# Altium schematic sheet Caudalimetro.SchDoc(Caudalimetro)
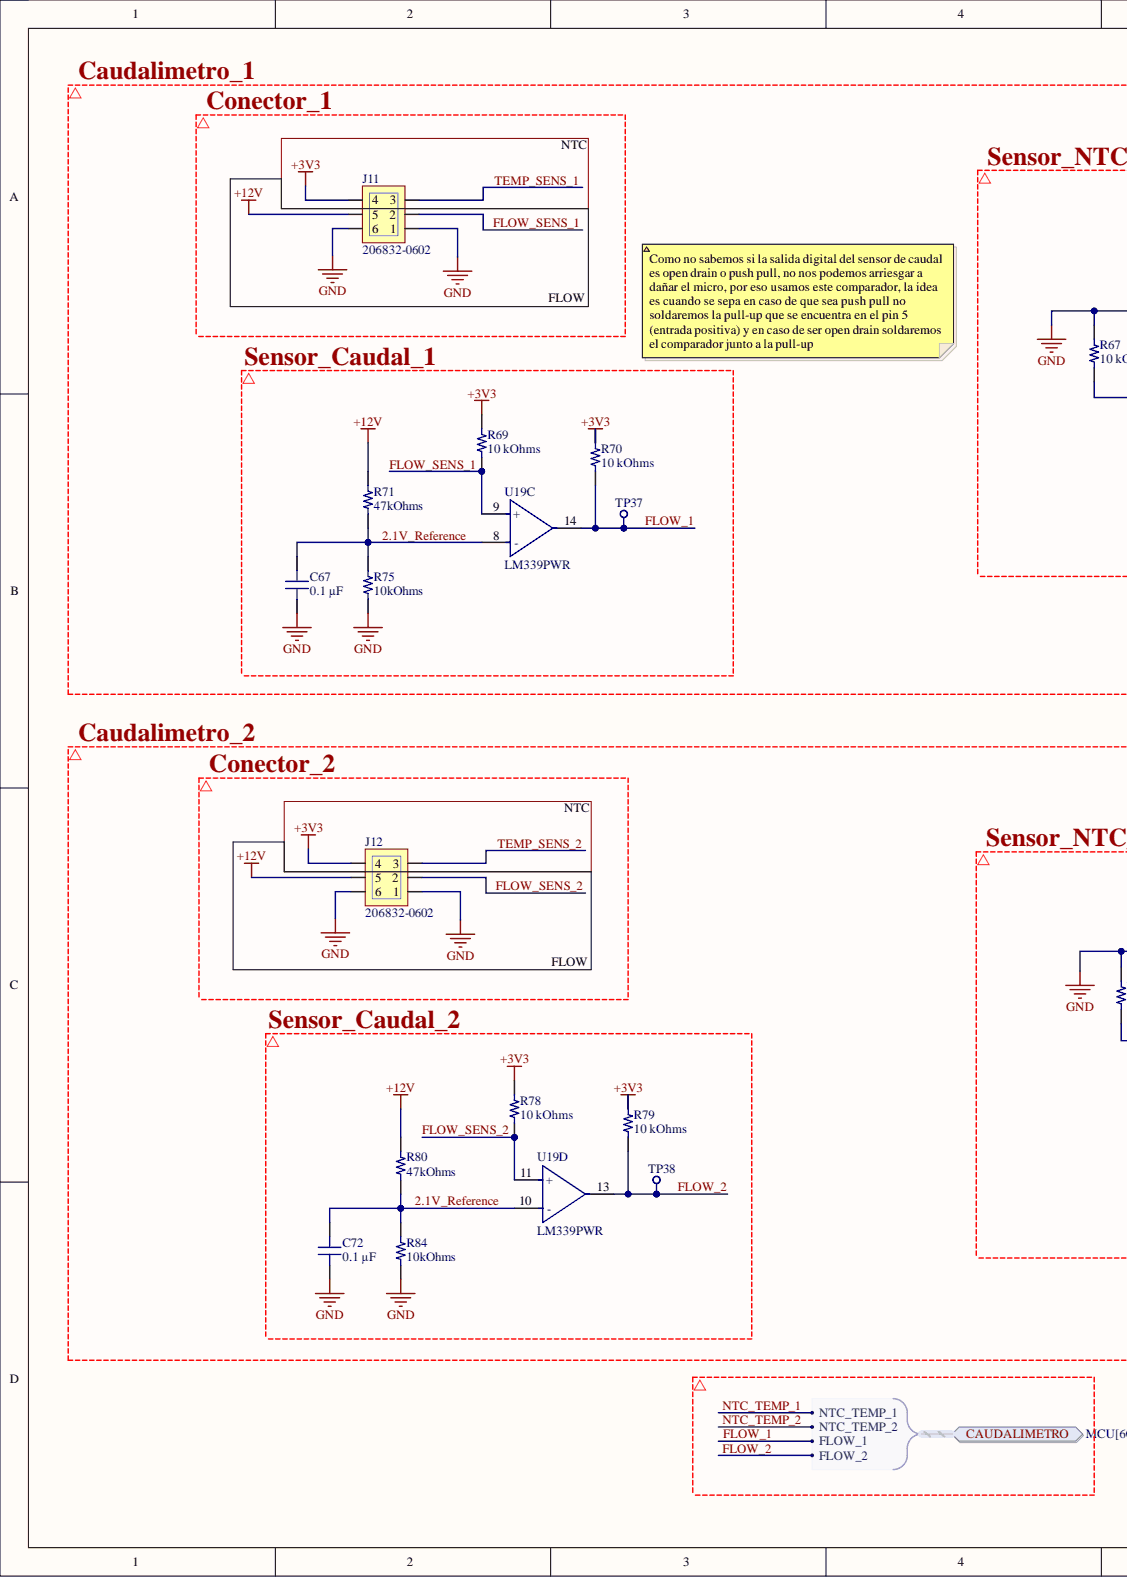
[diagram p0: Caudalimetro.SchDoc, left]
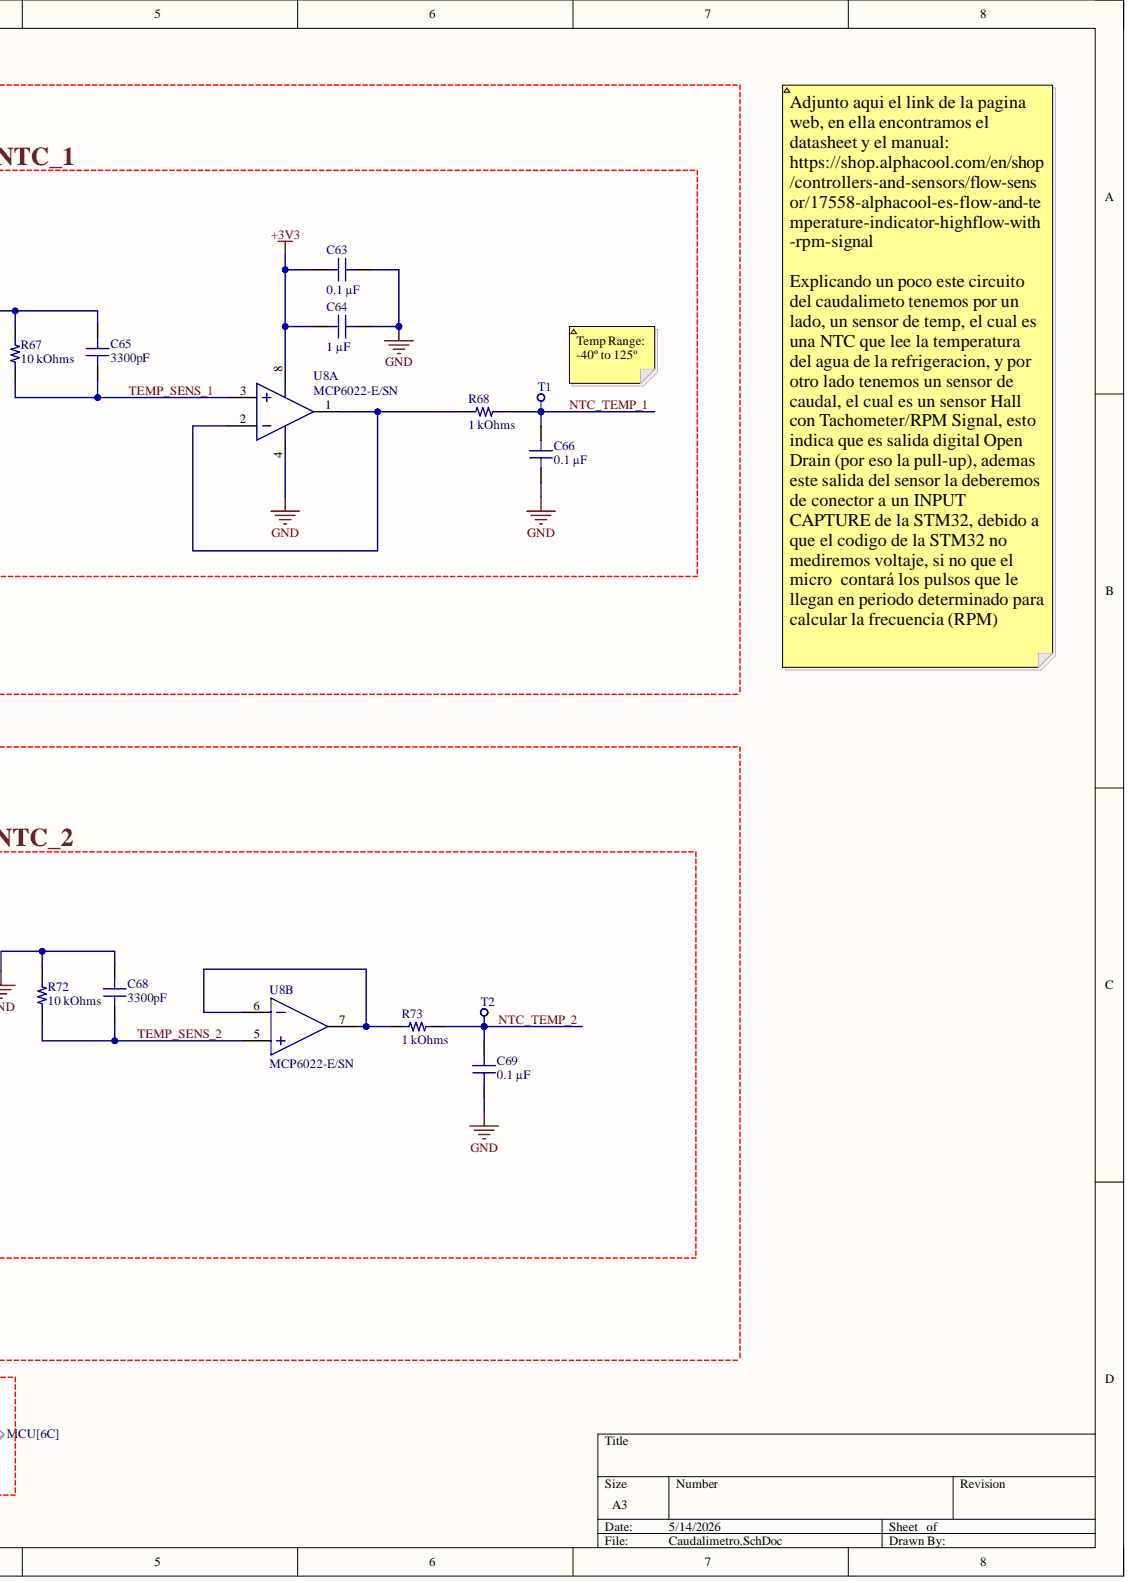
[diagram p1: Caudalimetro.SchDoc, right]

## Parts
C63  0.1 µF
C64  1 µF
C65  3300pF
C66  0.1 µF
C67  0.1 µF
C68  3300pF
C69  0.1 µF
C72  0.1 µF
J11  206832-0602
J12  206832-0602
R67  10 kOhms
R68  1 kOhms
R69  10 kOhms
R70  10 kOhms
R71  47kOhms
R72  10 kOhms
R73  1 kOhms
R75  10kOhms
R78  10 kOhms
R79  10 kOhms
R80  47kOhms
R84  10kOhms
T1  Test point 1.2mm  (value not drawn)
T2  Test point 1.2mm  (value not drawn)
TP37  Test point 1.2mm  (value not drawn)
TP38  Test point 1.2mm  (value not drawn)
U8  MCP6022-E/SN  (parts A,B)
U19  LM339PWR  (parts C,D)

## Ports
CAUDALIMETRO, CAUDALIMETRO.FLOW_1, CAUDALIMETRO.FLOW_2, CAUDALIMETRO.NTC_TEMP_1, CAUDALIMETRO.NTC_TEMP_2

## Nets
+3V3: C63.2 C64.1 J11.4 J12.4 R69.2 R70.2 R78.2 R79.2 U8.8
+12V: J11.5 J12.5 R71.2 R80.2
GND: C63.1 C64.2 C65.2 C66.1 C67.1 C68.2 C69.1 C72.1 J11.1 J11.6 J12.1 J12.6 R67.2 R72.2 R75.1 R84.1 U8.4
2.1V_Reference: C67.2 C72.2 R71.1 R75.2 R80.1 R84.2 U19.8(-) U19.10(-)
FLOW_1: R70.1 TP37.1 U19.14 ; port CAUDALIMETRO
FLOW_2: R79.1 TP38.1 U19.13 ; port CAUDALIMETRO
FLOW_SENS_1: J11.2 R69.1 U19.9(+)
FLOW_SENS_2: J12.2 R78.1 U19.11(+)
NTC_TEMP_1: C66.2 R68.2 T1.1 ; port CAUDALIMETRO
NTC_TEMP_2: C69.2 R73.2 T2.1 ; port CAUDALIMETRO
TEMP_SENS_1: C65.1 J11.3 R67.1 U8.3
TEMP_SENS_2: C68.1 J12.3 R72.1 U8.5
NetR68_1: R68.1 U8.1 U8.2
NetR73_1: R73.1 U8.6 U8.7

## Library data of the parts
C63 = C0603X104K050T: CAP CER 0.1UF 50V X7R 0603; manufacturer: Holy Stone Enterprise Co., Ltd.; Database Table Name: Capacitors - Ceramic - 0603; Tolerance: ±10%; Applications: SMPS Filtering; Capacitance: 0.1 µF; Component Kind: Standard; componentlink1description: Datasheet; componentlink1url: https://www.holystonecaps.com/PDF/201612051329340.2017%20NCC%20Series.pdf; componentlink2description: DigiKey Link; componentlink2url: https://www.digikey.com/en/products/detail/holy-stone-enterprise-co-ltd/C0603X104K050T/16894915; Device Package: 0603 (1608 Metric); lastupdated: 2/9/2024 8:09:56 PM; Library Name: Celestial Altium Library - altium_library.DbLib; Lifecycle Status: Active; Minimum Order: 1; Mounting Type: Surface Mount, MLCC; Operating Temperature: -55°C ~ 125°C; Package / Case: 0603 (1608 Metric); packaging: TapeAndReel; Part Number: C0603X104K050T; Part Status: Active; partid: 35023; price: 0.01; series: MVC; Size / Dimension: 0.063" L x 0.031" W (1.60mm x 0.80mm); Supplier 1: DigiKey; Supplier Part Number 1: 4587-C0603X104K050TCT-ND; Temperature Coefficient: X7R; Thickness (Max): 0.039" (1.00mm); Value: 0.1 µF; Voltage - Rated: 50V
C64 = C1206C105K5RACAUTO: CAP CER 1UF 50V X7R 1206; manufacturer: KEMET; Database Table Name: Capacitors - Ceramic - 1206; Tolerance: ±10%; Applications: Automotive, Bypass, Decoupling; Capacitance: 1 µF; Component Kind: Standard; componentlink1description: Datasheet; componentlink1url: https://api.kemet.com/component-edge/download/datasheet/C1206C105K5RACAUTO.pdf; componentlink2description: DigiKey Link; componentlink2url: https://www.digikey.com/en/products/detail/kemet/C1206C105K5RACAUTO/3315003; Device Package: 1206 (3216 Metric); lastupdated: 6/4/2024 7:10:32 PM; Library Name: Celestial Altium Library - altium_library.DbLib; Lifecycle Status: Active; Minimum Order: 1; Mounting Type: Surface Mount, MLCC; Operating Temperature: -55°C ~ 125°C; Package / Case: 1206 (3216 Metric); packaging: TapeAndReel; Part Number: C1206C105K5RACAUTO; Part Status: Active; partid: 19104; price: 0.05; Ratings: AEC-Q200; series: SMD Auto X7R; Size / Dimension: 0.126" L x 0.063" W (3.20mm x 1.60mm); Supplier 1: DigiKey; Supplier Part Number 1: 399-7011-1-ND; Temperature Coefficient: X7R; Thickness (Max): 0.043" (1.10mm); Value: 1 µF; Voltage - Rated: 50V
C65 C68 = 06035C332JAT2A: CAP CER 3300PF 50V X7R 0603; manufacturer: AVX Corporation; Database Table Name: Capacitors - Ceramic - 0603; Tolerance: ±5%; Applications: General Purpose; Capacitance: 3300pF; Component Kind: Standard; componentlink1description: Datasheet; componentlink1url: http://datasheets.avx.com/X7RDielectric.pdf; componentlink2description: DigiKey Link; componentlink2url: http://digikey.com/product-detail/en/avx-corporation/06035C332JAT2A/478-10808-1-ND/7536175; Device Package: 0603 (1608 Metric); lastupdated: 9/2/2020 1:03:35 AM; Library Name: Celestial Altium Library - altium_library.DbLib; Lifecycle Status: Active; Minimum Order: 1; Mounting Type: Surface Mount, MLCC; Operating Temperature: -55°C ~ 125°C; Package / Case: 0603 (1608 Metric); packaging: TapeAndReel; Part Number: 06035C332JAT2A; Part Status: Active; partid: 2774; price: 0.02; series: -; Size / Dimension: 0.063" L x 0.032" W (1.60mm x 0.81mm); Supplier 1: DigiKey; Supplier Part Number 1: 478-10808-1-ND; Temperature Coefficient: X7R; Thickness (Max): 0.035" (0.90mm); Value: 3300pF; Voltage - Rated: 50V
C66 C67 C69 C72 = CGA3E2X7R1H104K080AE: CAP CER 0.1UF 50V X7R 0603; manufacturer: TDK Corporation; Database Table Name: Capacitors - Ceramic - 0603; Tolerance: ±10%; Applications: Automotive, Boardflex Sensitive; Capacitance: 0.1 µF; Component Kind: Standard; componentlink1description: Datasheet; componentlink1url: https://product.tdk.com/info/en/catalog/datasheets/mlcc_automotive_soft_en.pdf?ref_disty=digikey; componentlink2description: DigiKey Link; componentlink2url: https://www.digikey.com/en/products/detail/tdk-corporation/CGA3E2X7R1H104K080AE/4490594; Device Package: 0603 (1608 Metric); Features: Soft Termination; Library Name: Celestial Altium Library - altium_library.DbLib; Lifecycle Status: Active; Minimum Order: 1; Mounting Type: Surface Mount, MLCC; Operating Temperature: -55°C ~ 125°C; Package / Case: 0603 (1608 Metric); packaging: TapeAndReel; Part Number: CGA3E2X7R1H104K080AE; Part Status: Active; partid: 2715; price: 0.01; Ratings: AEC-Q200; series: CGA; Size / Dimension: 0.063" L x 0.031" W (1.60mm x 0.80mm); Supplier 1: DigiKey; Supplier Part Number 1: 445-15957-1-ND; Temperature Coefficient: X7R; Thickness (Max): 0.037" (0.95mm); Value: 0.1 µF; Voltage - Rated: 50V
  C66 C69: lastupdated: 2/9/2024 8:10:29 PM
  C67 C72: lastupdated: 19/09/2022 13:01:18
J11 J12 = CMP-00106-5: Conector macho para PCB Molex serie Micro-Fit+ de 6 vías, 2 filas, paso 3.0mm, Montaje en orificio pasante; Component Kind: Standard; Library Name: Hyperloop UPV
R67 R69 R72 R78 = MCT06030D1002BP500: RES SMD 10K OHM 0.1% 1/10W 0603; Manufacturer: Vishay Beyschlag; Database Table Name: Resistors - Surface Mount - 0603; Power (Watts): 0.1W, 1/10W; Temperature Coefficient: ±25ppm/°C; Component Kind: Standard; ComponentLink1Description: Datasheet; ComponentLink1URL: http://www.vishay.com/docs/28700/mcx0x0xpre.pdf; ComponentLink2Description: DigiKey Link; ComponentLink2URL: http://digikey.com/product-detail/en/vishay-beyschlag/MCT06030D1002BP500/MCT0603-10K-DBCT-ND/2607937; Composition: Thin Film; Device Package: 0603 (1608 Metric); Features: Anti-Sulfur; Height - Seated (Max): 0.022" (0.55mm); LastUpdated: 2/9/2024 8:43:33 PM; Library Name: Celestial Altium Library - altium_library.DbLib; Lifecycle Status: Active; Minimum Order: 1; Number of Terminations: 2; Operating Temperature: -55°C ~ 125°C; Package / Case: 0603 (1608 Metric); Packaging: TapeAndReel; Part Number: MCT06030D1002BP500; Part Status: Active; PartId: 5804; Price: 0.14; Resistance: 10 kOhms; Series: MCT - Precision; Size / Dimension: 0.061" L x 0.033" W (1.55mm x 0.85mm); Supplier 1: DigiKey; Supplier Device Package: 0603; Supplier Part Number 1: MCT0603-10K-DBCT-ND; Tolerance: ±0.1%; Value: 10 kOhms
R68 R73 = RT0603FRE071KL: RES SMD 1K OHM 1% 1/10W 0603; Manufacturer: Yageo; Database Table Name: Resistors - Surface Mount - 0603; Power (Watts): 0.1W, 1/10W; Temperature Coefficient: ±50ppm/°C; Component Kind: Standard; ComponentLink1Description: Datasheet; ComponentLink1URL: https://www.yageo.com/upload/media/product/productsearch/datasheet/rchip/PYu-RT_1-to-0.01_RoHS_L_11.pdf; ComponentLink2Description: DigiKey Link; ComponentLink2URL: https://www.digikey.com/en/products/detail/yageo/RT0603FRE071KL/1075188; Composition: Thin Film; Device Package: 0603 (1608 Metric); Height - Seated (Max): 0.022" (0.55mm); LastUpdated: 7/25/2024 9:19:32 AM; Library Name: Celestial Altium Library - altium_library.DbLib; Lifecycle Status: Active; Minimum Order: 1; Number of Terminations: 2; Operating Temperature: -55°C ~ 155°C; Package / Case: 0603 (1608 Metric); Packaging: TapeAndReel; Part Number: RT0603FRE071KL; Part Status: Active; PartId: 5672; Price: 0.01; Resistance: 1 kOhms; Series: RT; Size / Dimension: 0.063" L x 0.031" W (1.60mm x 0.80mm); Supplier 1: DigiKey; Supplier Device Package: 0603; Supplier Part Number 1: YAG2323CT-ND; Tolerance: ±1%; Value: 1 kOhms
R70 R79 = RNCS0603BKE10K0: RES 10K OHM 0.1% 1/16W 0603; Manufacturer: Stackpole Electronics Inc; Database Table Name: Resistors - Surface Mount - 0603; Power (Watts): 0.063W, 1/16W; Temperature Coefficient: ±25ppm/°C; Component Kind: Standard; ComponentLink1Description: Datasheet; ComponentLink1URL: https://www.seielect.com/catalog/sei-rncs_rnch.pdf; ComponentLink2Description: DigiKey Link; ComponentLink2URL: https://www.digikey.com/en/products/detail/stackpole-electronics-inc/RNCS0603BKE10K0/1708836; Composition: Thin Film; Device Package: 0603 (1608 Metric); Features: Anti-Corrosive, Automotive AEC-Q200, Moisture Resistant; Height - Seated (Max): 0.022" (0.55mm); LastUpdated: 09-Feb-24 20:43:37; Library Name: Celestial Altium Library - altium_library.DbLib; Lifecycle Status: Active; Minimum Order: 1; Number of Terminations: 2; Operating Temperature: -55°C ~ 155°C; Package / Case: 0603 (1608 Metric); Packaging: TapeAndReel; Part Number: RNCS0603BKE10K0; Part Status: Active; PartId: 4838; Price: 0.06; Ratings: AEC-Q200; Resistance: 10 kOhms; Series: RNCS; Size / Dimension: 0.061" L x 0.031" W (1.55mm x 0.80mm); Supplier 1: DigiKey; Supplier Device Package: 0603; Supplier Part Number 1: RNCS0603BKE10K0CT-ND; Tolerance: ±0.1%; Value: 10 kOhms
R71 R80 = RT0603FRE07100KL: RES SMD 100K OHM 1% 1/10W 0603; Manufacturer: Yageo; Database Table Name: Resistors - Surface Mount - 0603; Power (Watts): 0.1W, 1/10W; Resistance: 100 kOhms; Temperature Coefficient: ±50ppm/°C; Component Kind: Standard; ComponentLink1Description: Datasheet; ComponentLink1URL: https://www.yageo.com/upload/media/product/productsearch/datasheet/rchip/PYu-RT_1-to-0.01_RoHS_L_11.pdf; ComponentLink2Description: DigiKey Link; ComponentLink2URL: https://www.digikey.com/en/products/detail/yageo/RT0603FRE07100KL/1075029; Composition: Thin Film; Device Package: 0603 (1608 Metric); Height - Seated (Max): 0.022" (0.55mm); LastUpdated: 25-Jul-24 09:19:32; Library Name: Celestial Altium Library - altium_library.DbLib; Lifecycle Status: Active; Minimum Order: 1; Number of Terminations: 2; Operating Temperature: -55°C ~ 155°C; Package / Case: 0603 (1608 Metric); Packaging: TapeAndReel; Part Number: RT0603FRE07100KL; Part Status: Active; PartId: 5677; Price: 0.01; Series: RT; Size / Dimension: 0.063" L x 0.031" W (1.60mm x 0.80mm); Supplier 1: DigiKey; Supplier Device Package: 0603; Supplier Part Number 1: YAG2319CT-ND; Tolerance: ±1%; Value: 47kOhms
R75 R84 = AC0603FR-07316KL: RES SMD 316K OHM 1% 1/10W 0603; Manufacturer: Yageo; Database Table Name: Resistors - Surface Mount - 0603; Power (Watts): 0.1W, 1/10W; Resistance: 316 kOhms; Temperature Coefficient: ±100ppm/°C; Component Kind: Standard; ComponentLink1Description: Datasheet; ComponentLink1URL: https://www.yageo.com/upload/media/product/productsearch/datasheet/rchip/PYu-AC_51_RoHS_L_8.pdf; ComponentLink2Description: DigiKey Link; ComponentLink2URL: https://www.digikey.com/en/products/detail/yageo/AC0603FR-07316KL/5896029; Composition: Thick Film; Device Package: 0603 (1608 Metric); Features: Automotive AEC-Q200, Moisture Resistant; Height - Seated (Max): 0.022" (0.55mm); LastUpdated: 25-Jul-24 09:19:12; Library Name: Celestial Altium Library - altium_library.DbLib; Lifecycle Status: Active; Minimum Order: 1; Number of Terminations: 2; Operating Temperature: -55°C ~ 155°C; Package / Case: 0603 (1608 Metric); Packaging: CutTape; Part Number: AC0603FR-07316KL; Part Status: Active; PartId: 61963; Price: 0.01; Ratings: AEC-Q200; Series: AC; Size / Dimension: 0.063" L x 0.031" W (1.60mm x 0.80mm); Supplier 1: DigiKey; Supplier Device Package: 0603; Supplier Part Number 1: 13-AC0603FR-07316KLCT-ND; Tolerance: ±1%; Value: 10kOhms
T1 T2 TP37 TP38 = CMP-006-00250-2: 1.2mm Test point; Component Kind: Standard; Library Name: Hyperloop UPV
U8 = CMP-00005-4: Operational Amplifier, 2 Func, 2500uV Offset-Max, CMOS, PDSO8; Architecture: VOLTAGE-FEEDBACK; Average Bias Current-Max (IIB): 5nA; Common-mode Reject Ratio-Nom: 90dB; Frequency Compensation: YES; Input Offset Voltage-Max: 250uV; Low-Offset: No; Max Supply Current: 2.7mA; Number of Functions: 2; Package Body Material: Plastic; Package Equivalence Code: SOP8,.25; Power Supplies: 3/5V; Qualification Status: Not Qualified; Slew Rate: 7V/µs; Supply Voltage Limit-Max: 7V; Supply Voltage-Nom (Vsup): 5V; Time @ Peak Reflow Temperature-Max (s): 40s; Unity Gain BW-Nom: 10MHz; Voltage Gain-Min: 31620; Amplifier Type: OPERATIONAL AMPLIFIER; Component Kind: Standard; Height - Seated (Max): 1.75mm; JESD-30 Code: R-PDSO-G8; JESD-609 Code: e3; Length: 4.9mm; Low-Bias: Yes; Max Operating Temperature: 125°C; Micropower: No; Min Operating Temperature: -40°C; Moisture Sensitivity Level: 3; Number of Pins: 8; Package Code: SOP; Package Shape: Rectangular; Package Style: SMALL OUTLINEMeter; Packaging: Tape and Reel; Peak Reflow Temperature (Cel): 260°C; Power: No; Programmable Power: No; Reach SVHC Compliant: Yes; RoHS Compliant: Yes; Surface Mount: Yes; Technology: CMOS; Temperature Grade: AUTOMOTIVE; Terminal Finish: Tin; Terminal Form: Gull Wing; Terminal Pitch: 1.27mm; Terminal Position: DUAL; Wideband: No; Width: 3.91mm; Library Name: Hyperloop UPV
U19 = CMP-006-00238-4: Comparator, 4 Func, 5000uV Offset-Max, 300ns Response Time, BIPolar, PDSO14; Component Kind: Standard; Max Operating Temperature: 70°C; Min Operating Temperature: 0°C; PartId: 1; RoHS Compliant: Yes; Library Name: Hyperloop UPV
